# Altium schematic sheet MCU.SchDoc(MCU)
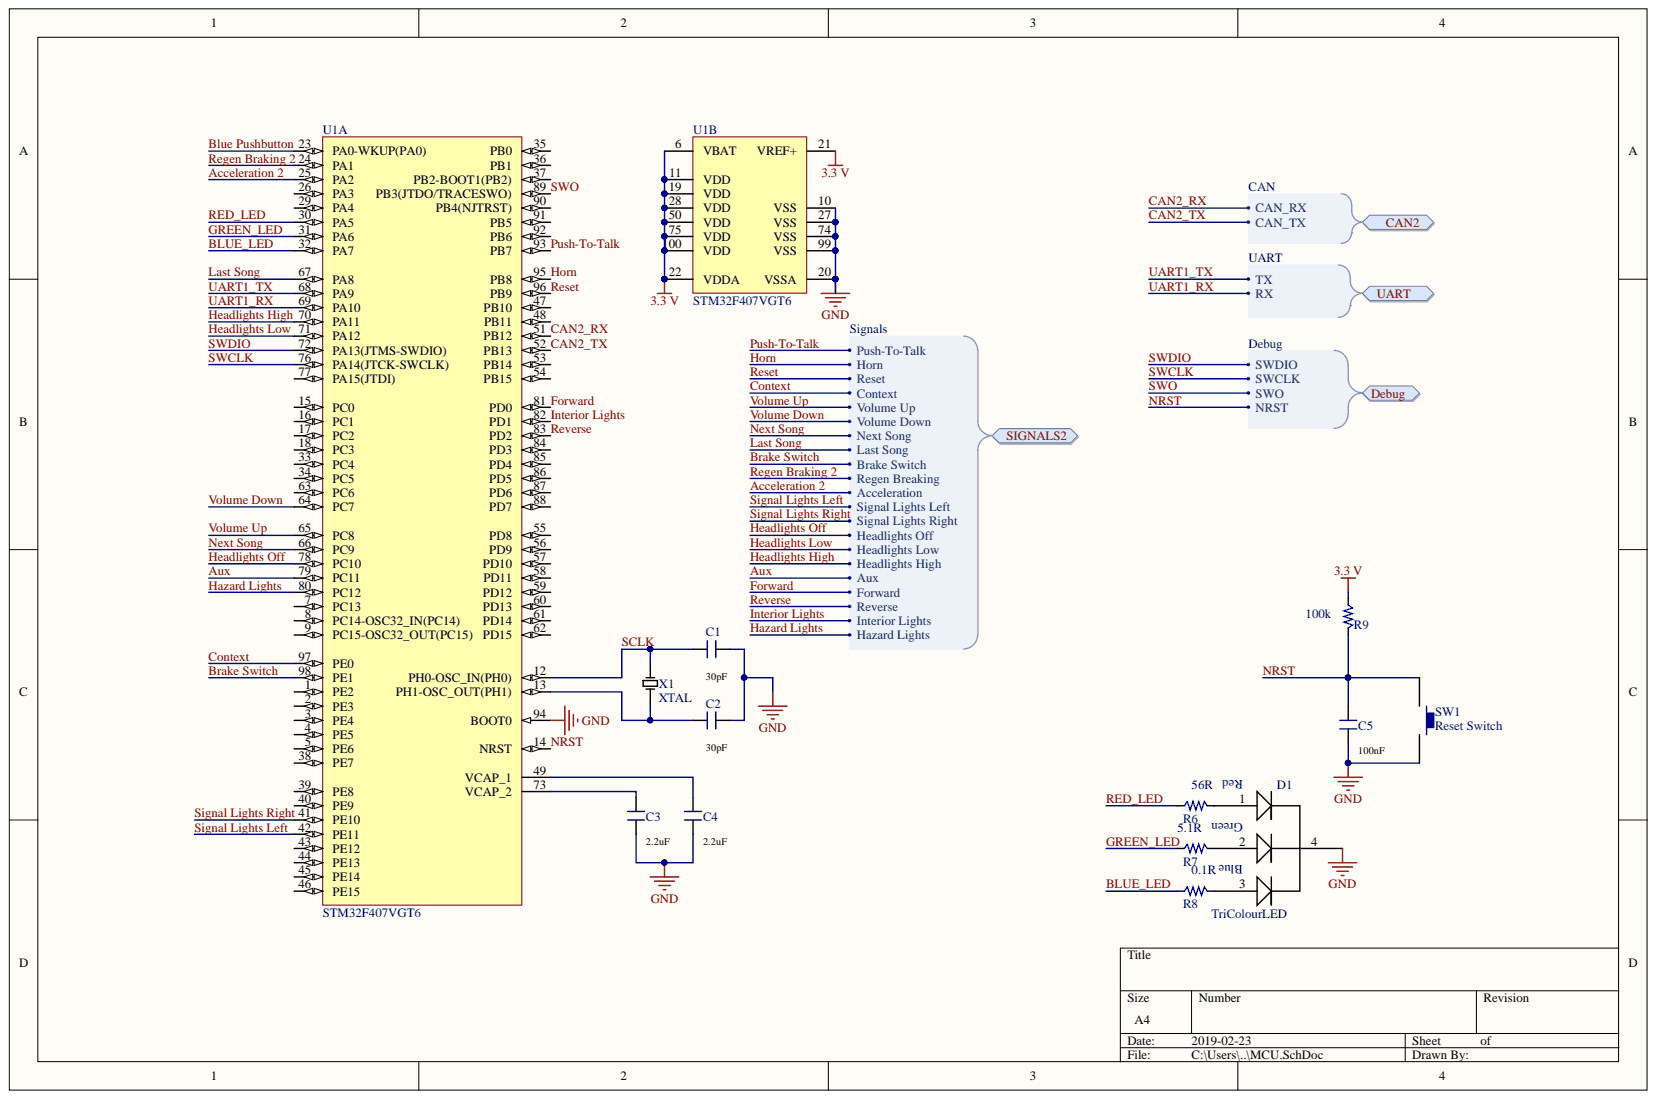
[diagram p0: MCU.SchDoc]

## Parts
C1  Capacitance: 30pF  [PCB_GENERIC_SMD_0603]  (hidden comment: SCH_GENERIC_CAPACITOR_0603)
C2  Capacitance: 30pF  [PCB_GENERIC_SMD_0603]  (hidden comment: SCH_GENERIC_CAPACITOR_0603)
C3  Capacitance: 2.2uF  [PCB_GENERIC_SMD_0603]  (hidden comment: SCH_GENERIC_CAPACITOR_0603)
C4  Capacitance: 2.2uF  [PCB_GENERIC_SMD_0603]  (hidden comment: SCH_GENERIC_CAPACITOR_0603)
C5  Capacitance: 100nF  [PCB_GENERIC_SMD_0603]  (hidden comment: SCH_GENERIC_CAPACITOR_0603)
D1  TriColourLED  [TricolourLED - SunLED - DK  1497-1225-2-ND]
R6  SCH_GENERIC_RESISTOR_0603  [PCB_GENERIC_SMD_0603]  (value not drawn)
R7  SCH_GENERIC_RESISTOR_0603  [PCB_GENERIC_SMD_0603]  (value not drawn)
R8  SCH_GENERIC_RESISTOR_0603  [PCB_GENERIC_SMD_0603]  (value not drawn)
R9  Resistance: 100k  [PCB_GENERIC_SMD_0603]  (hidden comment: SCH_GENERIC_RESISTOR_0603)
SW1  Reset Switch  [Push Button Switch]
U1  STM32F407VGT6  [STM-LQFP100_M]  (parts A,B)
X1  XTAL  [CRYSTAL_8MHZ]

## Ports
CAN2, CAN2.CAN_RX, CAN2.CAN_TX, Debug, Debug.NRST, Debug.SWCLK, Debug.SWDIO, Debug.SWO, SIGNALS2, SIGNALS2.Acceleration, SIGNALS2.Aux, SIGNALS2.Brake Switch, SIGNALS2.Context, SIGNALS2.Forward, SIGNALS2.Hazard Lights, SIGNALS2.Headlights High, SIGNALS2.Headlights Low, SIGNALS2.Headlights Off, SIGNALS2.Horn, SIGNALS2.Interior Lights, SIGNALS2.Last Song, SIGNALS2.Next Song, SIGNALS2.Push-To-Talk, SIGNALS2.Regen Breaking, SIGNALS2.Reset, SIGNALS2.Reverse, SIGNALS2.Signal Lights Left, SIGNALS2.Signal Lights Right, SIGNALS2.Volume Down, SIGNALS2.Volume Up, UART, UART.RX, UART.TX

## Nets
3.3 V: R9.1 U1.6(VBAT) U1.11(VDD) U1.19(VDD) U1.21(VREF+) U1.22(VDDA) U1.28(VDD) U1.50(VDD) U1.75(VDD) U1.100(VDD)
GND: C1.1 C2.1 C3.1 C4.1 C5.2 D1.4 SW1.2 U1.10(VSS) U1.20(VSSA) U1.27(VSS) U1.74(VSS) U1.94(BOOT0) U1.99(VSS)
Acceleration 2: U1.25(PA2) ; port SIGNALS2
Aux: U1.79(PC11) ; port SIGNALS2
Blue Pushbutton: U1.23(PA0-WKUP(PA0))
BLUE_LED: R8.1 U1.32(PA7)
Brake Switch: U1.98(PE1) ; port SIGNALS2
CAN2_RX: U1.51(PB12) ; port CAN2
CAN2_TX: U1.52(PB13) ; port CAN2
Context: U1.97(PE0) ; port SIGNALS2
Forward: U1.81(PD0) ; port SIGNALS2
GREEN_LED: R7.1 U1.31(PA6)
Hazard Lights: U1.80(PC12) ; port SIGNALS2
Headlights High: U1.70(PA11) ; port SIGNALS2
Headlights Low: U1.71(PA12) ; port SIGNALS2
Headlights Off: U1.78(PC10) ; port SIGNALS2
Horn: U1.95(PB8) ; port SIGNALS2
Interior Lights: U1.82(PD1) ; port SIGNALS2
Last Song: U1.67(PA8) ; port SIGNALS2
Next Song: U1.66(PC9) ; port SIGNALS2
NRST: C5.1 R9.2 SW1.1 U1.14(NRST) ; port Debug
Push-To-Talk: U1.93(PB7) ; port SIGNALS2
RED_LED: R6.1 U1.30(PA5)
Regen Braking 2: U1.24(PA1) ; port SIGNALS2
Reset: U1.96(PB9) ; port SIGNALS2
Reverse: U1.83(PD2) ; port SIGNALS2
SCLK: C1.2 U1.12(PH0-OSC_IN(PH0)) X1.1
Signal Lights Left: U1.42(PE11) ; port SIGNALS2
Signal Lights Right: U1.41(PE10) ; port SIGNALS2
SWCLK: U1.76(PA14(JTCK-SWCLK)) ; port Debug
SWDIO: U1.72(PA13(JTMS-SWDIO)) ; port Debug
SWO: U1.89(PB3(JTDO/TRACESWO)) ; port Debug
UART1_RX: U1.69(PA10) ; port UART
UART1_TX: U1.68(PA9) ; port UART
Volume Down: U1.64(PC7) ; port SIGNALS2
Volume Up: U1.65(PC8) ; port SIGNALS2
NetC2_2: C2.2 U1.13(PH1-OSC_OUT(PH1)) X1.2
NetC3_2: C3.2 U1.73(VCAP_2)
NetC4_2: C4.2 U1.49(VCAP_1)
NetD1_1: D1.1 R6.2
NetD1_2: D1.2 R7.2
NetD1_3: D1.3 R8.2

Not connected: U1 1(PE2) 2(PE3) 3(PE4) 4(PE5) 5(PE6) 7(PC13) 8(PC14-OSC32_IN(PC14)) 9(PC15-OSC32_OUT(PC15)) 15(PC0) 16(PC1) 17(PC2) 18(PC3) 26(PA3) 29(PA4) 33(PC4) 34(PC5) 35(PB0) 36(PB1) 37(PB2-BOOT1(PB2)) 38(PE7) 39(PE8) 40(PE9) 43(PE12) 44(PE13) 45(PE14) 46(PE15) 47(PB10) 48(PB11) 53(PB14) 54(PB15) 55(PD8) 56(PD9) 57(PD10) 58(PD11) 59(PD12) 60(PD13) 61(PD14) 62(PD15) 63(PC6) 77(PA15(JTDI)) 84(PD3) 85(PD4) 86(PD5) 87(PD6) 88(PD7) 90(PB4(NJTRST)) 91(PB5) 92(PB6)

## Library data of the parts
C1-C5 = SCH_GENERIC_CAPACITOR_0603: Generic SMD capacitor 0603; Tolerance: *%; Library Name: Capacitors.IntLib; Component Kind: Standard
R6 = SCH_GENERIC_RESISTOR_0603: Generic SMD resistor 0603; Resistance: 56R; Library Name: Generic 0603 Resistor.SchLib; Component Kind: Standard
R7 = SCH_GENERIC_RESISTOR_0603: Generic SMD resistor 0603; Resistance: 5.1R; Library Name: Generic 0603 Resistor.SchLib; Component Kind: Standard
R8 = SCH_GENERIC_RESISTOR_0603: Generic SMD resistor 0603; Resistance: 0.1R; Library Name: Generic 0603 Resistor.SchLib; Component Kind: Standard
R9 = SCH_GENERIC_RESISTOR_0603: Generic SMD resistor 0603; Library Name: Generic 0603 Resistor.SchLib; Component Kind: Standard
SW1 = PushButtonSwitch: Library Name: PushButtonSwitch.SchLib; Component Kind: Standard
U1 = STM32F407VGT6: ARM Cortex-M4 32-bit MCU+FPU, 210 DMIPS, 1024 kB Flash, 192 kB Internal RAM, 82 I/Os, 100-pin LQFP, -40 to 85 degC, Tray; Manufacturer: STMicroelectronics; Supply Voltage(Vcc) min (V): 1.8; Supply Voltage(Vcc) max (V): 3.6; Operating Frequency(F) (Processor speed) (MHz): 168; Internal RAM Size (kB): 192; I/Os (High Current): 82; FLASH Size (Prog) (kB): 1024; D/A Converter: 2x12-bit; Supplier Part Number 4: 511-STM32F407VGT6; Supplier Part Number 3: 73T2333; Supplier Part Number 2: 2064368; Supplier Part Number 1: 497-11605-ND; Supplier 4: Mouser; Supplier 3: Newark; Supplier 2: Farnell; Supplier 1: Digi-Key; PartNumber: STM32F407VGT6; Packing: Tray; PackageVersion: Rev. 1, 06/2013; PackageReference: LQFP100; PackageDescription: 100-Pin Low Profile Quad Flat Package, 14 x 14 mm Body, 0.5 mm Pitch; Mounting Technology: Surface Mount; Library Name: STMicroelectronics STM32 F4.IntLib; DatasheetVersion: Rev. 4; Core: ARM Cortex-M4; ComponentLink3URL: http://www.st.com/st-web-ui/static/active/en/resource/technical/document/data_brief/DM00071938.pdf; ComponentLink3Description: Package Specification; ComponentLink2URL: http://www.st.com/st-web-ui/static/active/en/resource/technical/document/datasheet/DM00037051.pdf; ComponentLink2Description: Datasheet; ComponentLink1URL: http://www.st.com/web/en/home.html; ComponentLink1Description: Manufacturer URL; Component Kind: Standard
X1 = Crystal: Frequency: 8MHz; Library Name: Crystal.SchLib; Component Kind: Standard
D1 = TriColourLED: Library Name: TricolourLED - SunLED - DK 1497-1225-2-ND.SchLib; Component Kind: Standard
